# Altium schematic sheet Potentiometer Sensors.SchDoc("Potentiometer Sensors")
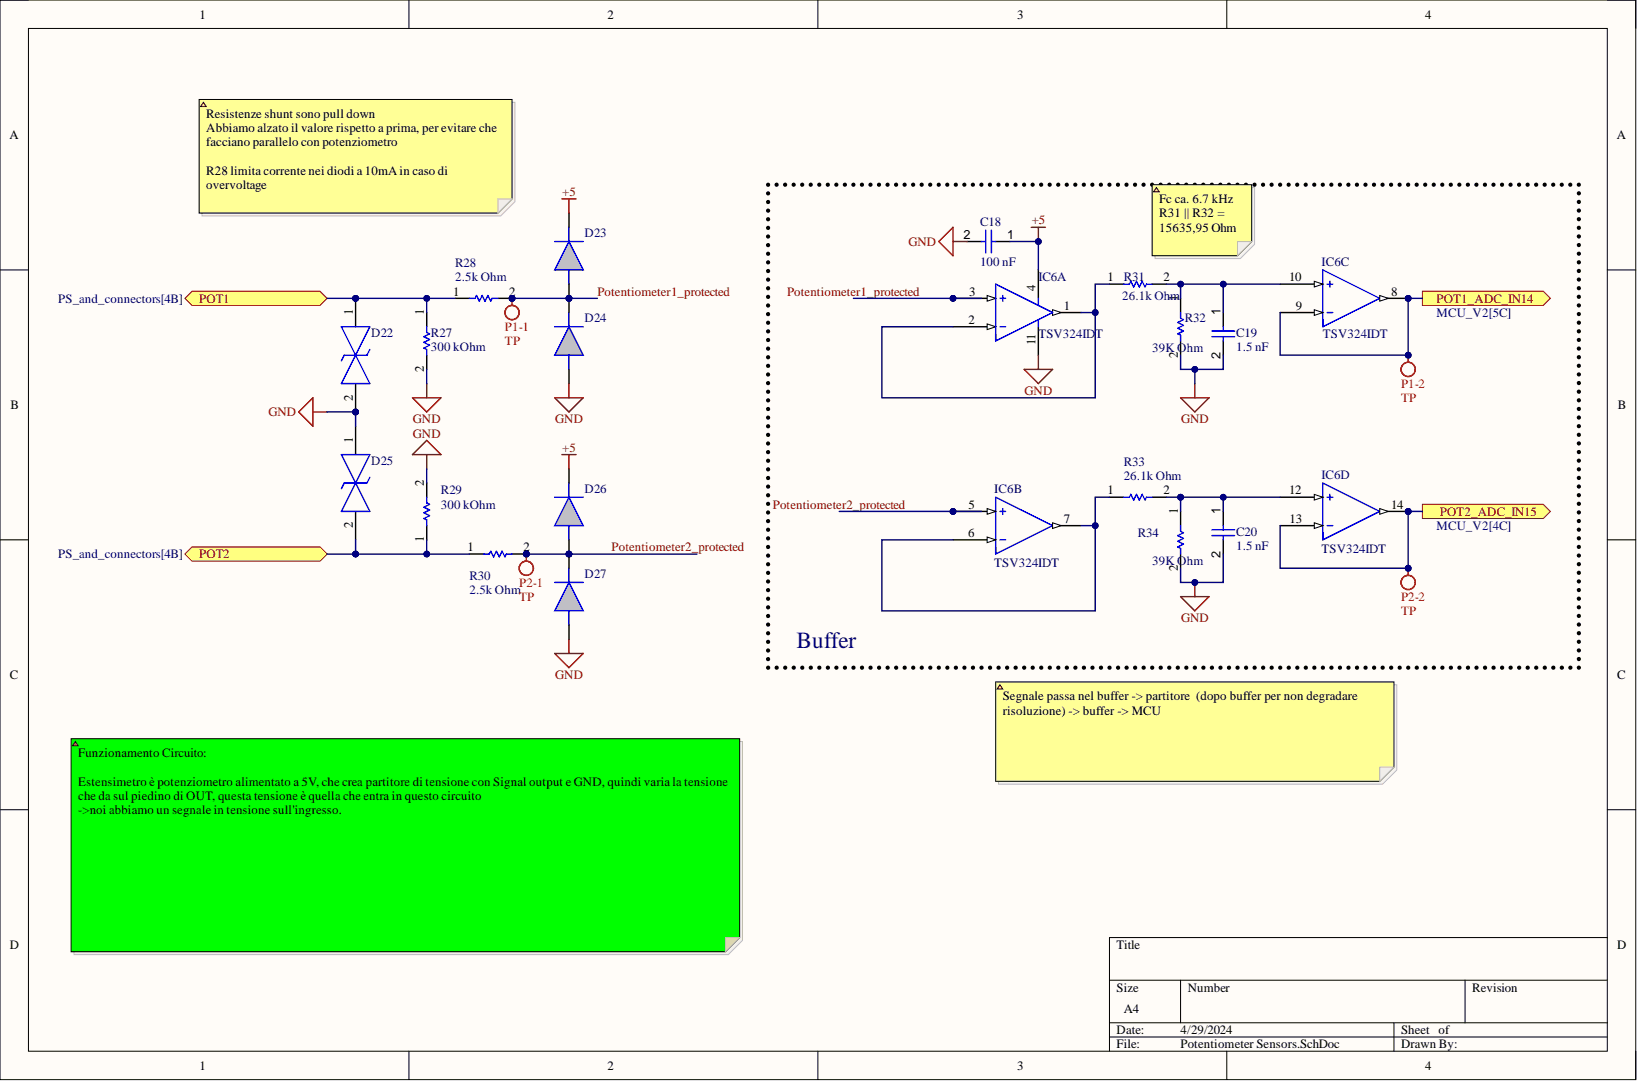
[diagram p0: Potentiometer Sensors.SchDoc]

## Parts
C18  100 nF
C19  1.5 nF
C20  1.5 nF
D22  SMAJ30CA-TR  (value not drawn)
D23  CD0603-B0340R  (value not drawn)
D24  CD0603-B0340R  (value not drawn)
D25  SMAJ30CA-TR  (value not drawn)
D26  CD0603-B0340R  (value not drawn)
D27  CD0603-B0340R  (value not drawn)
IC6  TSV324IDT  (parts A,B,C,D)
P1-1  TP
P1-2  TP
P2-1  TP
P2-2  TP
R27  300 kOhm
R28  2.5k Ohm
R29  300 kOhm
R30  2.5k Ohm
R31  26.1k Ohm
R32  39K Ohm
R33  26.1k Ohm
R34  39K Ohm

## Ports
POT1, POT1_ADC_IN14, POT2, POT2_ADC_IN15

## Nets
+5: C18.1 D23.1 D26.1 IC6.4
GND: C18.2 C19.2 C20.2 D22.2 D24.2 D25.1 D27.2 IC6.11 R27.2 R29.2 R32.2 R34.2
POT1: D22.1 R27.1 R28.1 ; port POT1
POT1_ADC_IN14: IC6.8 IC6.9 P1-2.1 ; port POT1_ADC_IN14
POT2: D25.2 R29.1 R30.1 ; port POT2
POT2_ADC_IN15: IC6.13 IC6.14 P2-2.1 ; port POT2_ADC_IN15
Potentiometer1_protected: D23.2 D24.1 IC6.3 P1-1.1 R28.2
Potentiometer2_protected: D26.2 D27.1 IC6.5 P2-1.1 R30.2
NetC19_1: C19.1 IC6.10 R31.2 R32.1
NetC20_1: C20.1 IC6.12 R33.2 R34.1
NetIC6_1: IC6.1 IC6.2 R31.1
NetIC6_6: IC6.6 IC6.7 R33.1

## Library data of the parts
C18 = CMP-00009-1: VJ0805Y104MXATW1BC; Component Kind: Standard; Library Name: EMEA- Squadra Corse PoliTO-  Politecnico di Torino
C19 C20 = CMP-00009-1: 885012207061; Component Kind: Standard; Library Name: EMEA- Squadra Corse PoliTO-  Politecnico di Torino
D22 D25 = CMP-004-000005-1: TVS Diode Bi-Directional SMAJ30CA-TR 64.3V; Manufacturer_Name: STMicroelectronics; Arrow Part Number: SMAJ30CA-TR; Arrow Price/Stock: https://www.arrow.com/en/products/smaj30ca-tr/stmicroelectronics?region=nac; Component Kind: Standard; Datasheet Link: https://www.st.com/resource/en/datasheet/smaj10ca.pdf; Design Item ID: SMAJ30CA-TR; Height: 2.65mm; Manufacturer_Part_Number: SMAJ30CA-TR; Mouser Part Number: 511-SMAJ30CA-TR; Mouser Price/Stock: https://www.mouser.co.uk/ProductDetail/STMicroelectronics/SMAJ30CA-TR?qs=0TJjK1waviDY0EkLaKH12g%3D%3D; Source: SamacSys.SchLib; Library Name: EMEA- Squadra Corse PoliTO-  Politecnico di Torino
D23 D24 D26 D27 = CMP-110-000000-5: Bourns 40V 300mA, Diode, 2-Pin 0603 CD0603-B0340R; Geometry.Height: 0.8mm; Component Kind: Standard; Datasheet Link: https://www.bourns.com/docs/product-datasheets/cd0603-b.pdf; Design Item ID: CD0603-B0340R; Manufacturer_Name: Bourns; Manufacturer_Part_Number: CD0603-B0340R; Mouser Part Number: 652-CD0603-B0340R; Mouser Price/Stock: https://www.mouser.co.uk/ProductDetail/Bourns/CD0603-B0340R?qs=xhbEVWpZdWee9K23%252B3DSjQ%3D%3D; Source: CD0603-B0340R.SchLib; Library Name: EMEA- Squadra Corse PoliTO-  Politecnico di Torino
IC6 = CMP-007-000004-4: Manufacturer_Name: STMicroelectronics; Arrow Part Number: TSV324IDT; Arrow Price/Stock: https://www.arrow.com/en/products/tsv324idt/stmicroelectronics; Component Kind: Standard; Datasheet Link: http://www.st.com/st-web-ui/static/active/en/resource/technical/document/datasheet/CD00063292.pdf; Description: TSV324IDT; Design Item ID: TSV324IDT; Height: 1.75mm; Manufacturer_Part_Number: TSV324IDT; Mouser Part Number: 511-TSV324IDT; Mouser Price/Stock: https://www.mouser.com/Search/Refine.aspx?Keyword=511-TSV324IDT; Source: SamacSys.SchLib; Library Name: EMEA- Squadra Corse PoliTO-  Politecnico di Torino
P1-1 P1-2 P2-1 P2-2 = CMP-002-00071-2: Test Point; Component Kind: Standard; Library Name: EMEA- Squadra Corse PoliTO-  Politecnico di Torino
R27 = CMP-009-00179-5: CRCW0603300KFKEAHP; Tech: SMD; Component Kind: Standard; ComponentLink1Description: Website; Package: 0603; Library Name: EMEA- Squadra Corse PoliTO-  Politecnico di Torino
R28 R30 = CMP-009-00179-5: 594-MCT06030C2491FP5  sono 2.49k, da 2.5k non si trovano; Tech: SMD; Component Kind: Standard; ComponentLink1Description: Website; Package: 0603; Library Name: EMEA- Squadra Corse PoliTO-  Politecnico di Torino
R29 = CMP-009-00179-5: CRCW0603300KJNTA; Tech: SMD; Component Kind: Standard; ComponentLink1Description: Website; Package: 0603; Library Name: EMEA- Squadra Corse PoliTO-  Politecnico di Torino
R31 R33 = CMP-009-00179-5: RT0603BRD0726K1L; Tech: SMD; Component Kind: Standard; ComponentLink1Description: Website; Package: 0603; Library Name: EMEA- Squadra Corse PoliTO-  Politecnico di Torino
R32 R34 = CMP-009-00179-5: RT0603BRD0739KL; Tech: SMD; Component Kind: Standard; ComponentLink1Description: Website; Package: 0603; Library Name: EMEA- Squadra Corse PoliTO-  Politecnico di Torino
